# Altium schematic sheet Digital_IO.SchDoc
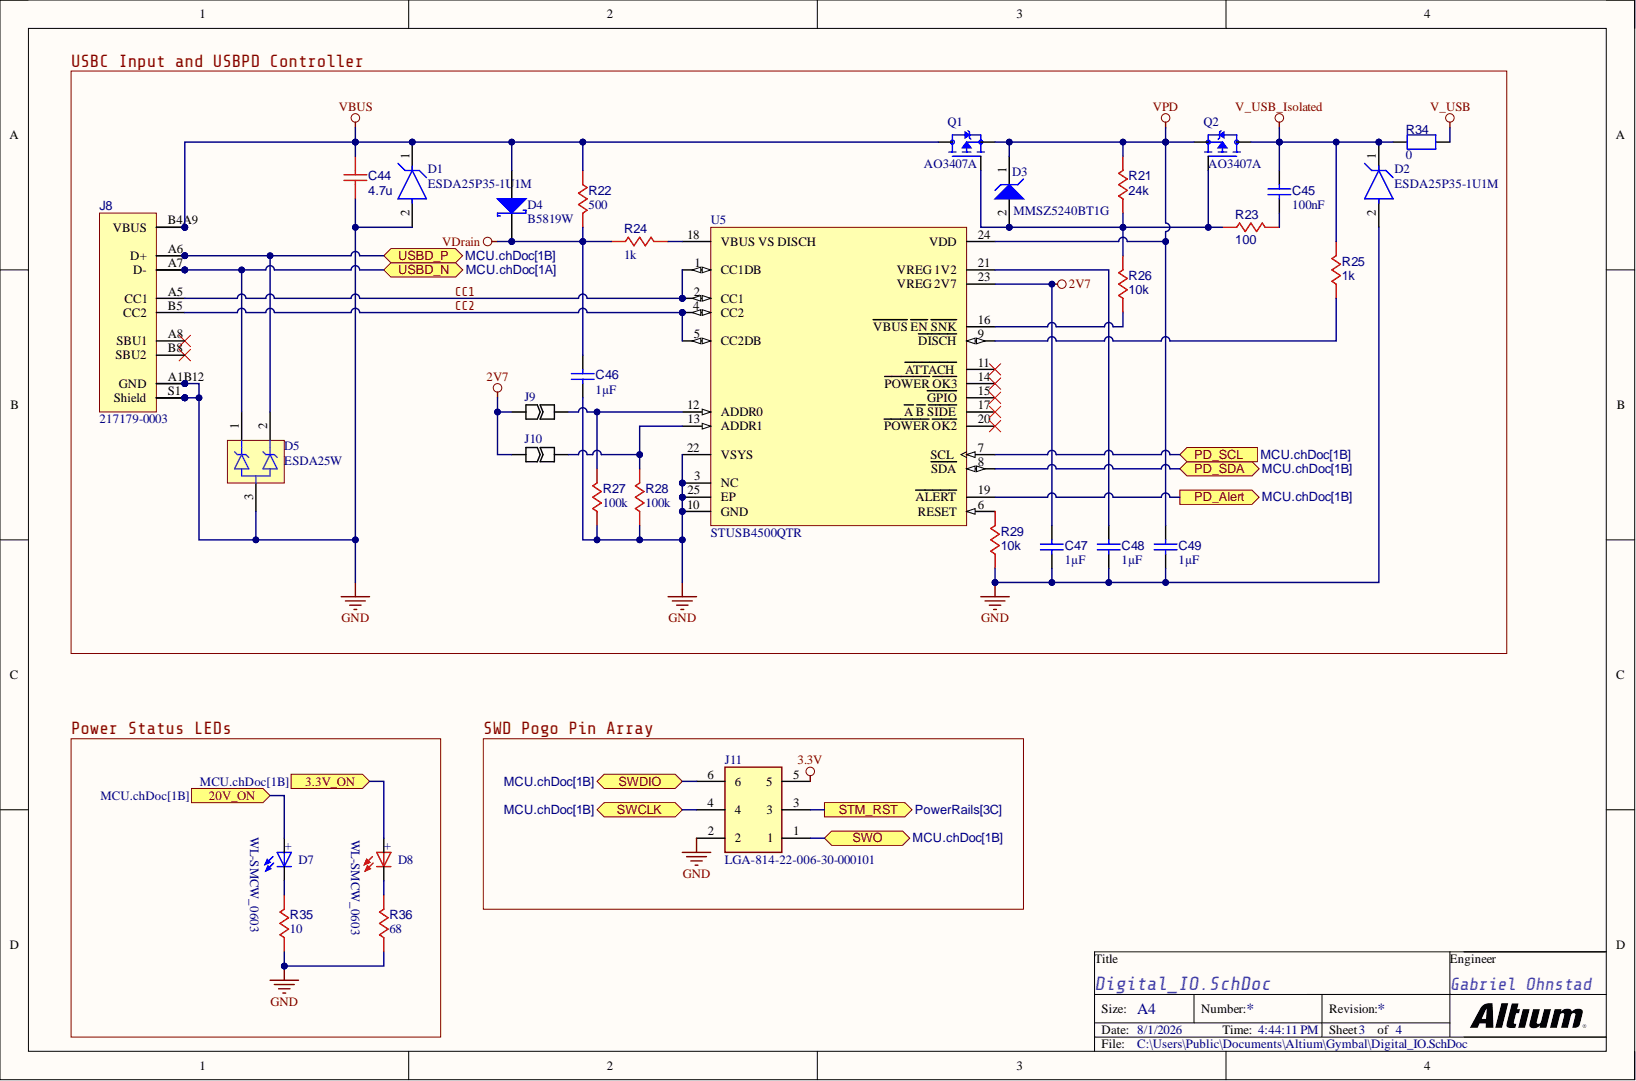
[diagram p0: Digital_IO.SchDoc]

## Parts
C44  value 4.7u  [CAPC0805(2012)145_L]  (hidden comment: Capacitors,0805,4.7u,50V)
C45  value 100nF  [CAPC0402(1005)60_L]  (hidden comment: Capacitor 100nF +/-20% 25V 0402)
C46  value 1µF  [CAPC2013X145X50ML20T25]  (hidden comment: Capacitor 1 uF +/- 10% 25 V 0805)
C47  value 1µF  [CAPC0402(1005)60_L]  (hidden comment: Capacitor 1µF +/-20% 10V 0402)
C48  value 1µF  [CAPC0402(1005)60_L]  (hidden comment: Capacitor 1µF +/-20% 10V 0402)
C49  value 1µF  [CAPC2013X145X50ML20T25]  (hidden comment: Capacitor 1 uF +/- 10% 25 V 0805)
D1  ESDA25P35-1U1M  [FP-QFN_1610-MFG]
D2  ESDA25P35-1U1M  [FP-QFN_1610-MFG]
D3  MMSZ5240BT1G  [ONSC-SOD-123-2-425-04_V]
D4  B5819W  [MCC-SOD123_V]
D5  ESDA25W  [FP-SOT323-3L-IPC_C]
D7  WL-SMCW_0603  [WL-SMCW_0603]
D8  WL-SMCW_0603  [WL-SMCW_0603]
J8  217179-0003  [217179-0003-Footprint-1]
J9  JUMPER  [JUMPER]  (value not drawn)
J10  JUMPER  [JUMPER]  (value not drawn)
J11  LGA-814-22-006-30-000101  [Alignment-8142200630000101]
Q1  AO3407A  [SOT95P280X125-3N]
Q2  AO3407A  [SOT95P280X125-3N]
R21  value 24k  [RESC0402(1005)_L]  (hidden comment: Resistors,0402,24k)
R22  value 500  [RESC0603(1608)_L]  (hidden comment: Resistors,0603,500)
R23  value 100  [RESC0402(1005)_L]  (hidden comment: Resistors,0402,100)
R24  value 1k  [RESC0603(1608)_L]  (hidden comment: Resistors,0603,1k)
R25  value 1k  [RESC0402(1005)_L]  (hidden comment: Resistors,0402,1k)
R26  value 10k  [RESC0402(1005)_L]  (hidden comment: Resistors,0402,10k)
R27  value 100k  [RESC0402(1005)_L]  (hidden comment: Resistors,0402,100k)
R28  value 100k  [RESC0402(1005)_L]  (hidden comment: Resistors,0402,100k)
R29  value 10k  [RESC0402(1005)_L]  (hidden comment: Resistors,0402,10k)
R34  value 0  [RESC0805(2012)_L]  (hidden comment: HCJ0805ZT0R00)
R35  value 10  [RESC0402(1005)_L]  (hidden comment: Resistors,0402,10)
R36  value 68  [RESC0402(1005)_L]  (hidden comment: Resistors,0402,68)
U5  STUSB4500QTR  [FP-QFN-24-EP_4x4-MFG]

## Ports
3.3V_ON, 20V_ON, PD_Alert, PD_SCL, PD_SDA, STM_RST, SWCLK, SWDIO, SWO, USBD_N, USBD_P

## Nets
2V7: C47.2 J9.1 J10.1 U5.23(VREG_2V7)
3.3V: J11.5
GND: C44.1 C46.1 C47.1 C48.1 C49.1 D1.2 D2.2 D5.3 J8.A1B12(GND) J8.B1A12 J8.S1(Shield) J8.S2 J8.S3 J8.S4 J11.2 R27.1 R28.1 R29.1 R35.1 R36.1 U5.3(NC) U5.10(GND) U5.22(VSYS) U5.25(EP)
V_USB: R34.1
V_USB_Isolated: C45.1 D2.1 Q2.3 R25.2 R34.2
VBUS: C44.2 D1.1 D4.1 J8.A4B9(VBUS) J8.B4A9 Q1.3 R22.2
VDrain: C46.2 D4.2 R22.1 R24.2
VPD: C49.2 D3.1 Q1.2 Q2.2 R21.2 U5.24(VDD)
3.3V_ON: D8.2 ; port 3.3V_ON
20V_ON: D7.2 ; port 20V_ON
CC1: J8.A5(CC1) U5.1(CC1DB) U5.2(CC1)
CC2: J8.B5(CC2) U5.4(CC2) U5.5(CC2DB)
PD_Alert: U5.19(ALERT) ; port PD_Alert
PD_SCL: U5.7(SCL) ; port PD_SCL
PD_SDA: U5.8(SDA) ; port PD_SDA
STM_RST: J11.3 ; port STM_RST
SWCLK: J11.4 ; port SWCLK
SWDIO: J11.6 ; port SWDIO
SWO: J11.1 ; port SWO
USBD_N: D5.1 J8.A7(D-) J8.B7 ; port USBD_N
USBD_P: D5.2 J8.A6(D+) J8.B6 ; port USBD_P
NetC45_2: C45.2 R23.2
NetC48_2: C48.2 U5.21(VREG_1V2)
NetD3_2: D3.2 Q1.1 Q2.1 R21.1 R23.1 R26.2
NetD7_1: D7.1 R35.2
NetD8_1: D8.1 R36.2
NetJ9_2: J9.2 R27.2 U5.12(ADDR0)
NetJ10_2: J10.2 R28.2 U5.13(ADDR1)
NetR24_1: R24.1 U5.18(VBUS_VS_DISCH)
NetR25_1: R25.1 U5.9(DISCH)
NetR26_1: R26.1 U5.16(VBUS_EN_SNK)
NetR29_2: R29.2 U5.6(RESET)

Not connected: J8 A8(SBU1) B8(SBU2); U5 11(ATTACH) 14(POWER_OK3) 15(GPIO) 17(A_B_SIDE) 20(POWER_OK2)

## Library data of the parts
C44 = Capacitors: Capacitor; Case/Package: 0805; Component Kind: Standard; Component Type: Capacitors; Voltage Rating: 50V; Library Name: Gabriel A Ohnstad
C45 = CMP-001-00065-7: Chip Capacitor, 100nF +/-20%, 25V, 0402, Thickness 0.6 mm; Tolerance: 10%; Case/Package: 0402; Component Kind: Standard; Max Operating Temperature: 85°C; Min Operating Temperature: -55°C; Mounting Technology: SMT; Pins: 2; Voltage Rating: 25V; Library Name: Gabriel A Ohnstad
C46 C49 = CMP-001-00010-9: Chip Capacitor, 1 uF, +/-10%, 25 V, 0805 (2012 Metric); Capacitor Type: Ceramic; Voltage Rating: 25V; Case/Package: 0805; Component Kind: Standard; Max Operating Temperature: 125°C; Min Operating Temperature: -55°C; Mounting Technology: SM; Pins: 2; RoHS Compliant: True; Tolerance: 10%; Library Name: Gabriel A Ohnstad
C47 C48 = CMP-001-00063-6: Chip Capacitor, 1µF +/-20%, 10V, 0402, Thickness 0.6 mm; Tolerance: 10%; Case/Package: 0402; Component Kind: Standard; Max Operating Temperature: 85°C; Min Operating Temperature: -55°C; Mounting Technology: SMT; Pins: 2; Voltage Rating: 10V; Library Name: Gabriel A Ohnstad
D1 D2 = CMP-12225-000030-1: TVS DIODE 22V 41V 1610; Manufacturer: STMicroelectronics; Breakdown Voltage: 23.3V; Clamping Voltage: 39V; Direction: Unidirectional; Max Junction Temp: +150°C; Number Of Channels: 1; Peak Pulse Current: 35A; Peak Pulse Power: 1400W; Standoff Height: 0.02mm; Automotive: No; Category: Diode; Component Kind: Standard; ComponentLink2Description: Datasheet; ComponentLink2URL: https://www.st.com/resource/en/datasheet/esda25p35-1u1m.pdf; Device Class L1: Circuit Protection; Device Class L2: TVS Diodes; Device Class L3: unset; Digikey Description: TVS DIODE 22V 41V 1610; Height: 0.6mm; Lead Free: Yes; Library Name: Altium Content Vault; Manufacturer Part Number: ESDA25P35-1U1M; Mouser Description: ESDA Series 1400 W 25 V Uni-Directional Surface Mount TVS Diode - QFN-1610; Octopart: https://octopart.com/esda25p35-1u1m-stmicroelectronics-75302699; Package: QFN_1610; Reverse Standoff Voltage: 22V; RoHS: TRUE; Temperature: -55°C to +150°C; Temperature Range High: +150°C; Temperature Range Low: -55°C
D3 = CMP-1060-00549-1: Zener Voltage Regulator, 500 mW, 2-Pin SOD-123, Pb-Free, Tape and Reel; Manufacturer: On Semiconductor; P Max (W): 0.5; VZ Typ (V): 10; Component Kind: Standard; ComponentLink1Description: Manufacturer URL; ComponentLink1URL: http://www.onsemi.com/; ComponentLink2Description: Datasheet; ComponentLink2URL: http://www.onsemi.com/pub_link/Collateral/MMSZ5221BT1-D.PDF; ComponentLink3Description: Package Specification; ComponentLink3URL: http://www.onsemi.com/pub_link/Collateral/425-04.PDF; DatasheetVersion: Rev. 12; Library Name: Altium Content Vault; Mounting Technology: Surface Mount; PackageDescription: 2-Pin SOD, Body 1.6 x 2.69 mm; PackageReference: SOD-123-2-425-04; PackageVersion: Rev. G, 10/2009; Packing: Tape and Reel; PartNumber: MMSZ5240BT1G; RoHS: Pb-Free
D4 = CMP-004-00005-2: Schottky Barrier Rectifier, 40 V, 1 A, -65 to 125 degC, 2-Pin SOD123, RoHS, Tape and Reel; Manufacturer: Micro Commercial Components; Case/Package: SOD123; Component Kind: Standard; Datasheet URL: http://www.mccsemi.com/up_pdf/B5817W-B5819W(SOD-123).pdf; Datasheet Version: Rev. B, 01/2013; Manufacturer URL: http://www.mccsemi.com/; Mounting Technology: SM; Package Description: 2-Pin Small Outline Diode, Body 2.7 x 1.6 mm, Height 1.35 mm; Package Reference: SOD123; Package URL: http://www.mccsemi.com/up_pdf/1N4448W(SOD-123).pdf; RoHS Compliant: Unknown; Library Name: Gabriel A Ohnstad
D5 = CMP-004-00032-1: 25V 400W 25V 24V SOT-323-3L ESD and Surge Protection (TVS/ESD) ROHS; Breakdown Voltage: 27.5V; Direction: Unidirectional; Element Configuration: Common Anode; ESD Protection: Yes; Forward Voltage: 1.2V; Leakage Current: 1µA; Max Breakdown Voltage: 30V; Max Junction Temperature (Tj): 125°C; Number of Channels: 2; Power Line Protection: No; Power Rating: 150W; Test Current: 1mA; Value: 65pF; Case/Package: SOT-323; China RoHS: Compliant; Component Kind: Standard; Composition: Zener; Country of Origin: Mainland China; Depth: 1.25mm; Export Control Classification Number (ECCN) Code: EAR99; Harmonized Tariff Schedule (HTS) Code: 8541.10.00.50; Height: 1.1mm; Introduction Date: 1980-01-04; Lead Free: Lead Free; Length: 2mm; Lifecycle Status: Production; Max Operating Temperature: 125°C; Max Power Dissipation: 400W; Max Reverse Leakage Current: 1µA; Min Breakdown Voltage: 25V; Min Operating Temperature: -40°C; Mounting Technology: Surface Mount; Number of Elements: 2; Number of Terminals: 3; Number of Unidirectional Channels: 2; Operating Supply Voltage: 1.2V; Peak Pulse Power: 400W; Peak Reverse Current: 1µA; Pins: 3; Polarity: Unidirectional; Radiation Hardening: No; REACH SVHC: Yes; Reverse Breakdown Voltage: 25V; Reverse Standoff Voltage: 24V; RoHS: Compliant; Schedule B: 8536300000, 8536300000; Termination: SMD/SMT; Voltage Rating (DC): 25V; Width: 1.25mm; Working Voltage: 24V; Library Name: Gabriel A Ohnstad
D7 = CMP-004-00035-2: 0603, Blue Typ.(@20mA): 470nm, 145mcd, 3.2V, 140Â° InGaN; MF: Wurth Electronics; MP: 150060BS75000; Case/Package: 0603 Wurth Electronics; Check_prices: https://www.snapeda.com/parts/150060BS75000/Wurth+Electronics/view-part/?ref=eda; Component Kind: Standard; Component Type: Standard; Design Item ID: WL-SMCW_0603; Pins: 2; SnapEDA_Link: https://www.snapeda.com/parts/150060BS75000/Wurth+Electronics/view-part/?ref=snap; Source: WL-SMCW_0603.SchLib; Library Name: Gabriel A Ohnstad
D8 = CMP-004-00036-3: 0603, Red Typ.(@20mA): 625nm, 250mcd, 2.0V, 140Â° AlInGaP; MF: Wurth Electronics; MP: 150060RS75000; Case/Package: 0603 WÃ¼rth Elektronik; Check_prices: https://www.snapeda.com/parts/150060RS75000/Wurth+Electronics/view-part/?ref=eda; Component Kind: Standard; Component Type: Standard; Design Item ID: WL-SMCW_0603; Pins: 2; SnapEDA_Link: https://www.snapeda.com/parts/150060RS75000/Wurth+Electronics/view-part/?ref=snap; Source: WL-SMCW_0603.SchLib; Library Name: Gabriel A Ohnstad
J8 = CMP-002-00084-5: Conn USB Type C RCP 16 POS 0.5mm Solder RA SMD 16 Terminal 1 Port USB T/R; Manufacturer: Molex; Connector Type: USBC; Gender: Receptacle; Max Current Rating: 5A; Case/Package: None; Component Kind: Standard; Component Type: Standard; Lifecycle Status: Production; RoHS: Compliant; Library Name: Gabriel A Ohnstad
J9 J10 = CMP-009-00061-10: Component Kind: Standard; Description: JUMPER; Pins: 2; Library Name: Gabriel A Ohnstad
J11 = CMP-002-00083-5: MILL MAX - 814-22-006-30-000101 - CONNECTOR, HEADER, 2.54MM, 6POS, SMT; Manufacturer_Name: Mill-Max; Geometry.Height: 6.48mm; Arrow Part Number: 814-22-006-30-000101; Arrow Price/Stock: https://www.arrow.com/en/products/814-22-006-30-000101/mill-max; Component Kind: Standard; Datasheet Link: https://www.mill-max.com/products/datasheet/sockets/814-22-006-30-000101; Design Item ID: 814-22-006-30-000101; Manufacturer_Part_Number: 814-22-006-30-000101; Mouser Part Number: 575-8142200630000101; Mouser Price/Stock: https://www.mouser.com/Search/Refine.aspx?Keyword=575-8142200630000101; Source: 814-22-006-30-000101.SchLib; Library Name: Gabriel A Ohnstad
Q1 Q2 = CMP-012-000000-1: P-Channel 30 V 4.3A (Ta) 1.4W (Ta) Surface Mount SOT-23-3; MF: Alpha &; Check_prices: https://www.snapeda.com/parts/AO3407A/Alpha/view-part/?ref=eda; Component Kind: Standard; Component Type: Standard; Design Item ID: AO3407A; MP: AO3407A; Package: SOT-23 Alpha &amp; Omega Semiconductor; Pin Count: 3; SnapEDA_Link: https://www.snapeda.com/parts/AO3407A/Alpha/view-part/?ref=snap; Source: AO3407A.SchLib; Library Name: Gabriel A Ohnstad
R21-R26 = Resistors: Resistor; Component Kind: Standard; Component Type: Resistors; Library Name: Gabriel A Ohnstad
  R21 R23 R25 R26: Case/Package: 0402
  R22 R24: Case/Package: 0603
R27-R29 R35 R36 = Resistors: Resistor, Bootstrap; Case/Package: 0402; Component Kind: Standard; Component Type: Resistors; Library Name: Gabriel A Ohnstad
R34 = CMP-009-00179-2: HCJ Series 0805 Jumper Surface Mount Chip Resistor; Manufacturer Package Identifier: 0805 (2012 metric); DNP: TRUE; Power Rating: 500mW; Case Code (Imperial): 0805; Case Code (Metric): 2012; Case/Package: 0805; Component Kind: Standard; Composition: Metal Strip; Country of Origin: Taiwan; Export Control Classification Number (ECCN) Code: EAR99; Harmonized Tariff Schedule (HTS) Code: 8533.21.00.30; Height: 650µm; Introduction Date: 2013-09-03; Lead Free: Lead Free; Length: 2mm; Lifecycle Status: Production; Max Operating Temperature: 155°C; Min Operating Temperature: -55°C; Mounting Technology: Surface Mount; Number of Terminals: 2; Number of Terminations: 2; Packaging: Cut Tape (CT); Radiation Hardening: No; Ratings: AEC-Q200; REACH SVHC: Yes; RoHS: Compliant; Schedule B: 8533210045, 8533210045; Termination: Wraparound; Width: 1.2mm; Library Name: Gabriel A Ohnstad
U5 = CMP-015-00008-2: Super Speed USB Controller USB 1.2/USB 2.0 5V/9V/12V/15V/18V T/R 24-Pin QFN EP; Interface: I2C, USB; Max Junction Temperature (Tj): 145°C; Max Supply Voltage: 22V; Min Supply Voltage: 4.1V; Nominal Supply Voltage (DC): 5V; Number of Channels: 1; USB Standard: USB 2.0; Ambient Temperature Range High: 105°C; China RoHS: Compliant; Component Kind: Standard; Country of Origin: Mainland China; Export Control Classification Number (ECCN) Code: EAR99; Harmonized Tariff Schedule (HTS) Code: 8542.39.00.60; Height: 1mm; Height - Seated (Max): 1mm; Introduction Date: 2018-04-09; Length: 4mm; Lifecycle Status: Production; Max Operating Temperature: 105°C; Min Operating Temperature: -40°C; Number of Circuits: 1; Number of Ports: 1; Number of Terminals: 26; REACH SVHC: Yes; RoHS: Compliant; Schedule B: 8542390000, 8542390000; Terminal Pitch: 500µm; Width: 4mm; Library Name: Gabriel A Ohnstad
